# Altium schematic sheet DAC.SchDoc(DAC)
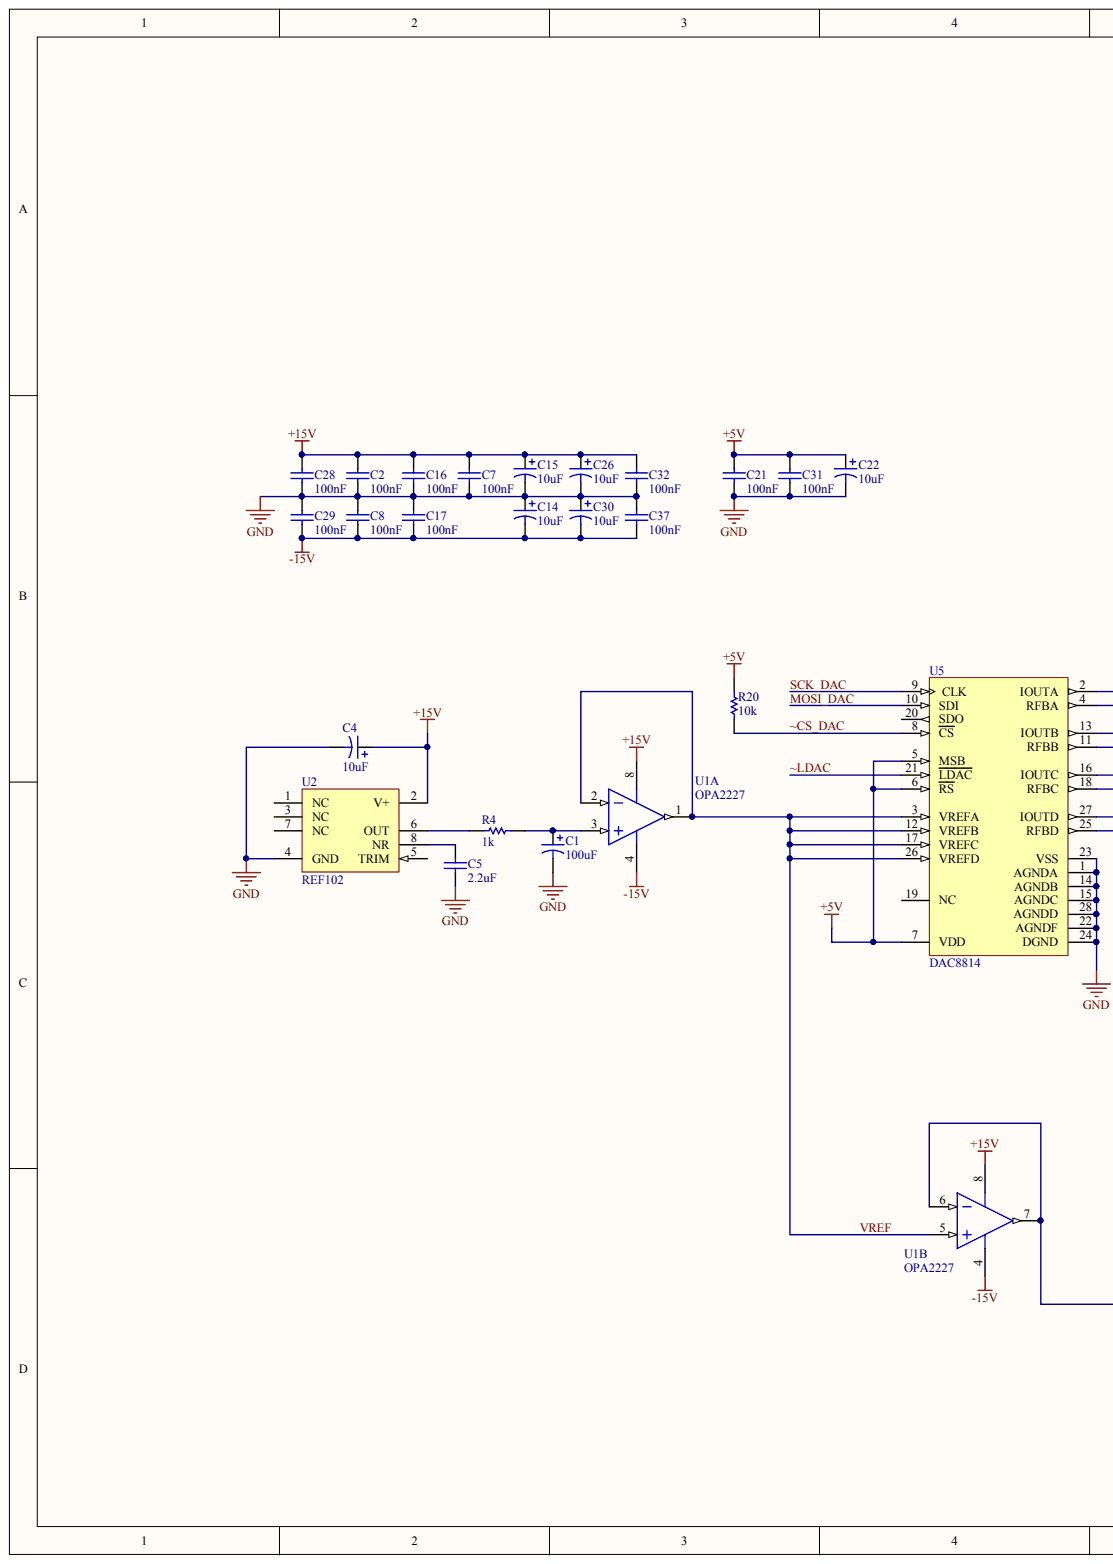
[diagram p0: DAC.SchDoc, left]
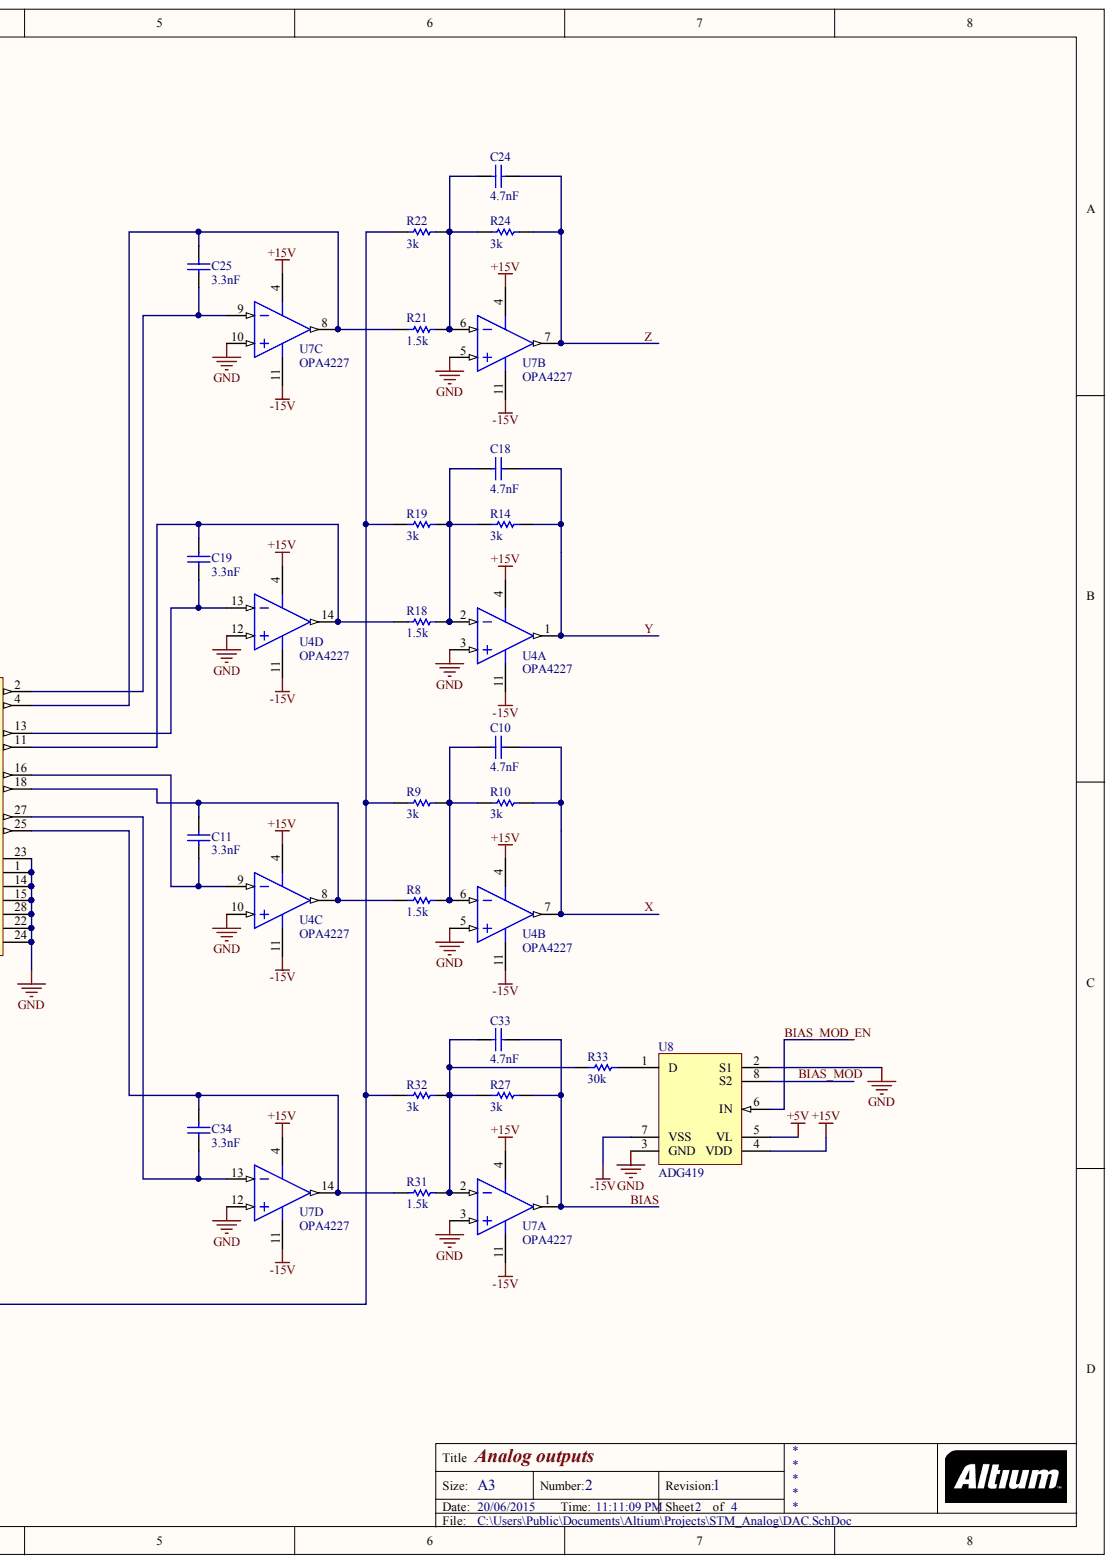
[diagram p1: DAC.SchDoc, right]

## Parts
C1  100uF  [7343]
C2  100nF  [6-0805_N]
C4  10uF  [3528]
C5  2.2uF  [C1206]
C7  100nF  [6-0805_N]
C8  100nF  [6-0805_N]
C10  4.7nF  [6-0805_N]
C11  3.3nF  [6-0805_N]
C14  10uF  [3528]
C15  10uF  [3528]
C16  100nF  [6-0805_N]
C17  100nF  [6-0805_N]
C18  4.7nF  [6-0805_N]
C19  3.3nF  [6-0805_N]
C21  100nF  [6-0805_N]
C22  10uF  [6-0805_N]
C24  4.7nF  [6-0805_N]
C25  3.3nF  [6-0805_N]
C26  10uF  [3528]
C28  100nF  [6-0805_N]
C29  100nF  [6-0805_N]
C30  10uF  [3528]
C31  100nF  [6-0805_N]
C32  100nF  [6-0805_N]
C33  4.7nF  [6-0805_N]
C34  3.3nF  [6-0805_N]
C37  100nF  [6-0805_N]
R4  1k  [6-0805_N]
R8  1.5k  [6-0805_N]
R9  3k  [6-0805_N]
R10  3k  [6-0805_N]
R14  3k  [6-0805_N]
R18  1.5k  [6-0805_N]
R19  3k  [6-0805_N]
R20  10k  [6-0805_N]
R21  1.5k  [6-0805_N]
R22  3k  [6-0805_N]
R24  3k  [6-0805_N]
R27  3k  [6-0805_N]
R31  1.5k  [6-0805_N]
R32  3k  [6-0805_N]
R33  30k  [6-0805_N]
U1  OPA2227  [ADI-R-8_M]  (parts A,B)
U2  REF102  [182H_N]
U4  OPA4227  [TI-D14_M]  (parts A,B,C,D)
U5  DAC8814  [TI-DB28_L]
U7  OPA4227  [TI-D14_M]  (parts A,B,C,D)
U8  ADG419  [ADI-RM-8_N]

## Nets
+5V: C21.2 C22.1 C31.2 R20.2 U5.5(MSB) U5.6(RS) U5.7(VDD) U8.5(VL)
+15V: C2.2 C4.1 C7.1 C15.1 C16.2 C26.1 C28.2 C32.2 U1.8 U2.2(V+) U4.4 U7.4 U8.4(VDD)
-15V: C8.1 C14.2 C17.1 C29.1 C30.2 C37.1 U1.4 U4.11 U7.11 U8.7(VSS)
GND: C1.2 C2.1 C4.2 C5.2 C7.2 C8.2 C14.1 C15.2 C16.1 C17.2 C21.1 C22.2 C26.2 C28.1 C29.2 C30.1 C31.1 C32.1 C37.2 U2.4(GND) U4.3 U4.5 U4.10 U4.12 U5.1(AGNDA) U5.14(AGNDB) U5.15(AGNDC) U5.22(AGNDF) U5.23(VSS) U5.24(DGND) U5.28(AGNDD) U7.3 U7.5 U7.10 U7.12 U8.2(S1) U8.3(GND)
BIAS: C33.2 R27.2 U7.1
BIAS_MOD: U8.8(S2)
BIAS_MOD_EN: U8.6(IN)
MOSI_DAC: U5.10(SDI)
SCK_DAC: U5.9(CLK)
VREF: U1.1 U1.2 U1.5 U5.3(VREFA) U5.12(VREFB) U5.17(VREFC) U5.26(VREFD)
X: C10.2 R10.2 U4.7
Y: C18.2 R14.2 U4.1
Z: C24.2 R24.2 U7.7
~CS_DAC: R20.1 U5.8(CS)
~LDAC: U5.21(LDAC)
NetC1_1: C1.1 R4.2 U1.3
NetC5_1: C5.1 U2.8(NR)
NetC10_1: C10.1 R8.2 R9.2 R10.1 U4.6
NetC11_1: C11.1 U4.9 U5.16(IOUTC)
NetC11_2: C11.2 R8.1 U4.8 U5.18(RFBC)
NetC18_1: C18.1 R14.1 R18.2 R19.2 U4.2
NetC19_1: C19.1 U4.13 U5.13(IOUTB)
NetC19_2: C19.2 R18.1 U4.14 U5.11(RFBB)
NetC24_1: C24.1 R21.2 R22.2 R24.1 U7.6
NetC25_1: C25.1 U5.2(IOUTA) U7.9
NetC25_2: C25.2 R21.1 U5.4(RFBA) U7.8
NetC33_1: C33.1 R27.1 R31.2 R32.2 R33.1 U7.2
NetC34_1: C34.1 U5.27(IOUTD) U7.13
NetC34_2: C34.2 R31.1 U5.25(RFBD) U7.14
NetR4_1: R4.1 U2.6(OUT)
NetR9_1: R9.1 R19.1 R22.1 R32.1 U1.6 U1.7
NetR33_2: R33.2 U8.1(D)

Not connected: U2 1(NC) 3(NC) 5(TRIM) 7(NC); U5 19(NC) 20(SDO)

## Library data of the parts
C1 C4 C14 C15 C22 C26 C30 = Cap Pol1: Polarized Capacitor (Radial); Value: 100pF; Component Kind: Standard; LatestRevisionDate: 17-Jul-2002; LatestRevisionNote: Re-released for DXP Platform.; Library Name: Miscellaneous Devices.IntLib; PackageDescription: Polarized Capacitor (Radial); 2 Leads; PackageReference: RB7.6-15; Published: 8-Jun-2000; Simulation: CAP; Publisher: Altium Limited
C2 C5 C7 C8 C10 C11 C16-C19 C21 C24 C25 C28 C29 C31-C34 C37 = Cap: Capacitor; Value: 100pF; Component Kind: Standard; LatestRevisionDate: 17-Jul-2002; LatestRevisionNote: Re-released for DXP Platform.; Library Name: Miscellaneous Devices.IntLib; PackageDescription: Radial Cap, Thru-Hole; 2 Leads; 0.3 in Pin Spacing; PackageReference: RAD-0.3; Published: 8-Jun-2000; Simulation: CAP; Publisher: Altium Limited
R4 = Res1: Resistor; Component Kind: Standard; LatestRevisionDate: 17-Jul-2002; LatestRevisionNote: Re-released for DXP Platform.; Library Name: Miscellaneous Devices.IntLib; PackageDescription: Axial Device, Thru-Hole; 2 Leads; 0.3 in Pin Spacing; PackageReference: AXIAL-0.3; Published: 8-Jun-2000; Simulation: RESISTOR; Value: 1K; Publisher: Altium Limited
R8-R10 R14 R18-R22 R24 R27 R31-R33 = Res1: Resistor; Value: 1K; Component Kind: Standard; LatestRevisionDate: 17-Jul-2002; LatestRevisionNote: Re-released for DXP Platform.; Library Name: Miscellaneous Devices.IntLib; PackageDescription: Axial Device, Thru-Hole; 2 Leads; 0.3 in Pin Spacing; PackageReference: AXIAL-0.3; Published: 8-Jun-2000; Simulation: RESISTOR; Publisher: Altium Limited
U1 = CMP-0384-01147-2: Double Channel Wide Bandwidth Operational Amplifier, 10 MHz BW, 2.5 V/us SR, Industrial, 8-pin SOIC (R-8), Tube; Generic Part Number: AD8676; Manufacturer: Analog Devices; PartNumber: AD8676BRZ; Amplifiers Per Package: 2; Input Bias Current: 2.4nA; Input Offset Voltage: 12uV; Iq/Amp: 2.7mA; Small Signal Bandwidth: 10MHz; V Span Max: 36V; V Span Min: 10V; Code_JEDEC: MS-012-AA; Component Kind: Standard; ComponentLink1Description: Manufacturer URL; ComponentLink1URL: http://www.analog.com/en/index.html; ComponentLink2Description: Datasheet; ComponentLink2URL: http://www.analog.com/static/imported-files/data_sheets/AD8676.pdf; ComponentLink3Description: Package Specification; ComponentLink3URL: http://www.analog.com/static/imported-files/packages/PKG_PDF/SOIC_Narrow%20(R)/R_8.pdf; ComponentLink4Description: Reference Circuit; ComponentLink4URL: http://www.analog.com/en/all-operational-amplifiers-op-amps/operational-amplifiers-op-amps/ad8676/products/product.html#product-recommendations; DatasheetVersion: Rev. C; Library Name: Altium Content Vault; Mounting Technology: Surface Mount; PackageDescription: 8-Pin Standard Small Outline Package, Body 3.9 x 4.9 mm, Pitch 1.27 mm; PackageReference: R-8; PackageVersion: 060506-A; RevisionNote: Reference Circuit URL fixed; Slew Rate: 2.5V/us
U2 = REF102AU: Precision Voltage Reference; Note: Pins 1,3 and 7 are No internal Connection (NC) pins.; Code_IPC: SOIC127P600-8; Code_JEDEC: MS-012-AA; Component Kind: Standard; ComponentLink1Description: Manufacturer Link; ComponentLink1URL: http://www.ti.com/; ComponentLink2Description: Datasheet; ComponentLink2URL: http://www-s.ti.com/sc/ds/REF102.pdf; DatasheetVersion: Oct-1993; LatestRevisionDate: 13-Apr-2006; LatestRevisionNote: IPC-7351 Footprint Added.; Library Name: BB Power Mgt Voltage Reference.IntLib; PackageDescription: 8-Pin Small Outline Integrated Circuit 1.27 mm Pitch; PackageReference: 182H; PCB3D: SOIC8; Published: 8-Jun-2000; Publisher: Altium Limited
U4 U7 = CMP-0272-00152-2: High Precision, Low Noise Operational Amplifier, 5 to 36 V, -40 to 85 degC, 14-pin SOIC (D14), Green (RoHS & no Sb/Br); Manufacturer: Texas Instruments; PartNumber: OPA4227UA/2K5; CMRR(Min)(dB): 120; GBW(Typ)(MHz): 8; IIB(Max)(pA): 10000; Iq per channel(Max)(mA): 3.8; Number of Channels: 4; Offset Drift(Typ)(uV/C): 0.3; Packing: LARGE T&R; Rating: Catalog; Slew Rate(Typ)(V/us): 2.3; Sub Family: High Supply Voltage Amplifier (>=30V), Low Noise Amp (<=10nV/rtHz), Low Offset Voltage Amplifier (<=500uV), Precision Amplifier; Total Supply Voltage(Max)(+5V is 5, +/-5V is 10): 36; Total Supply Voltage(Min)(+5V is 5, +/-5V is 10): 5; Vio (25C)(Max)(mV): 0.2; Code_JEDEC: MS-012; Component Kind: Standard; ComponentLink1Description: Manufacturer URL; ComponentLink1URL: http://www.ti.com/; ComponentLink2Description: Datasheet; ComponentLink2URL: http://www.ti.com/lit/ds/symlink/opa4227.pdf; ComponentLink3Description: Package Specification; ComponentLink3URL: http://www.ti.com/lit/ml/mpds177g/mpds177g.pdf; DatasheetVersion: SBOS110A; Eco-Plan: Green (RoHS & no Sb/Br); Library Name: Altium Content Vault; Mounting Technology: Surface Mount; PackageDescription: 14-Pin Plastic Small Outline, Body 8.65 x 3.9 mm, Pitch 1.27 mm; PackageReference: D14; PackageVersion: 4040047-5/M, 06/2011
U5 = CMP-0004-00286-1: 16-Bit, Quad, Serial Input Multiplying Digital to Analog Converter, -40 to 85 degC, 28-pin SOP (DB28), Green (RoHS & no Sb/Br); Manufacturer: Texas Instruments; PartNumber: DAC8814ICDBTG4; DAC Channels: 4; DNL(Max)(+/-LSB): 1; Interface: Serial SPI; Resolution(Bits): 16; Settling Time(us): 0.5; SubFamily: Precision DAC (=<10MSPS); Code_JEDEC: MO-150; Component Kind: Standard; ComponentLink1Description: Manufacturer URL; ComponentLink1URL: http://www.ti.com/; ComponentLink2Description: Datasheet; ComponentLink2URL: http://www.ti.com/general/docs/lit/getliterature.tsp?genericPartNumber=DAC8814&fileType=pdf; ComponentLink3Description: Package Specification; ComponentLink3URL: http://www.ti.com/lit/ml/msso002e/msso002e.pdf; DatasheetVersion: SBAS338D; Eco-Plan: Green (RoHS & no Sb/Br); INL(Max)(+/-LSB): 1; Library Name: Altium Content Vault; Mounting Technology: Surface Mount; PackageDescription: 28-Pin Plastic Small Outline, Body 10.2 X 5.3 mm, Pitch 0.65 mm; PackageReference: DB28; PackageVersion: 4040065/E, 12/2001
U8 = CMP-0792-00196-1: LC2MOS Precision Mini-DIP Analog Switches, 25 Ohm Ron, 8-pin SOP (RM-8), Tube; Manufacturer: Analog Devices; PartNumber: ADG419BRMZ; # Sw Functions: 1; Configuration: SPDT; Max Neg Supply: -16.5V; Max Pos Supply: +16.5V; Ron (ohms): 25, 40; Code_JEDEC: MO-187-AA; Component Kind: Standard; ComponentLink1Description: Manufacturer URL; ComponentLink1URL: http://www.analog.com/en/index.html; ComponentLink2Description: Datasheet; ComponentLink2URL: http://www.analog.com/static/imported-files/data_sheets/ADG419.pdf; ComponentLink3Description: Package Specification; ComponentLink3URL: http://www.analog.com/static/imported-files/packages/PKG_PDF/MSOP%28RM%29/RM_8.pdf; DatasheetVersion: Rev. C; Library Name: Altium Content Vault; Mounting Technology: Surface Mount; PackageDescription: 8-Pin Mini Small Outline Package, Body 3 x 3 mm, Pitch 0.65 mm; PackageReference: RM-8; PackageVersion: Aug-05; Packing: Tube
